# Altium schematic sheet Connectors.SchDoc
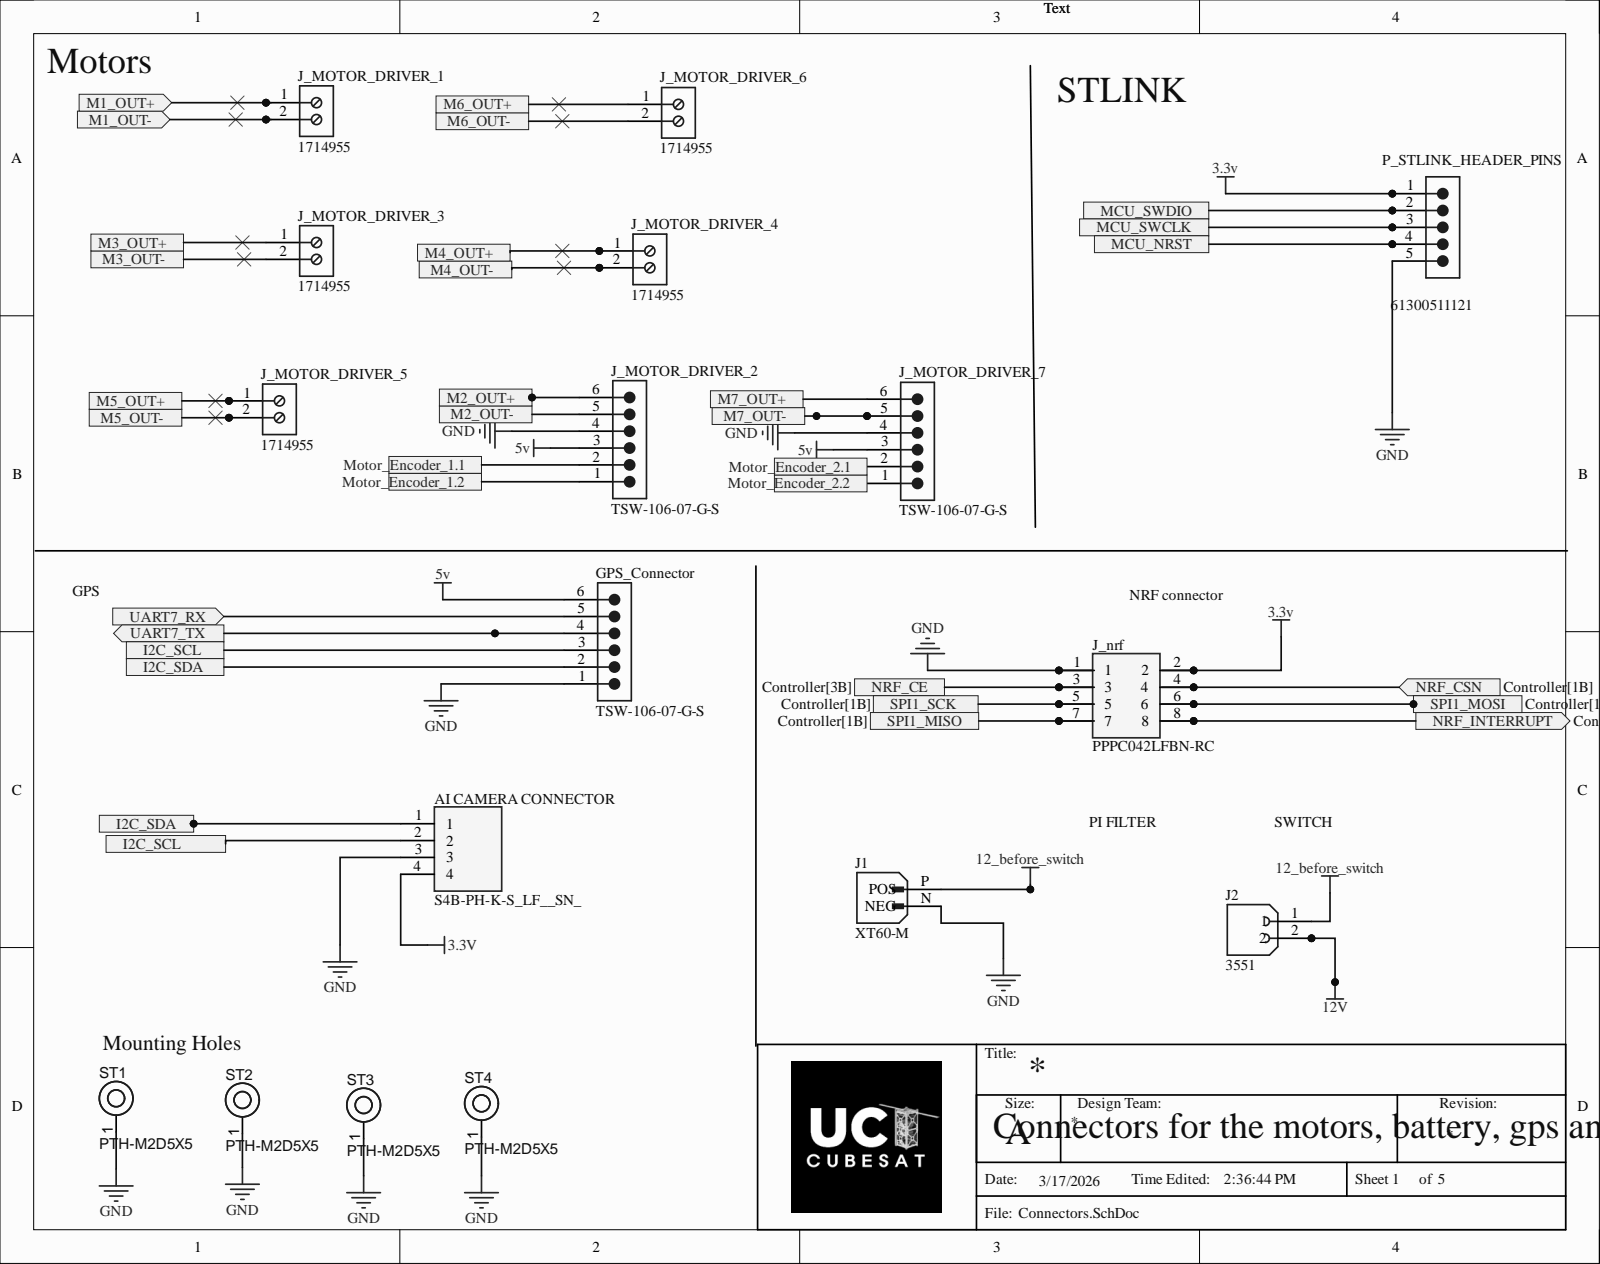
[diagram p0: Connectors.SchDoc]

## Parts
AI_CAMERA_CONNECTOR  S4B-PH-K-S_LF__SN_  [SHDRRA4W50P0X200_1X4_990X760X480P]
GPS_Connector  TSW-106-07-G-S  [SMTC-TSW-106-07-X-S]
J1  XT60-M  [AMASS_XT60-M]
J2  3551  [KEYSTONE_3551]
J_MOTOR_DRIVER_1  1714955  [PHOE-1714955_V]
J_MOTOR_DRIVER_2  TSW-106-07-G-S  [SMTC-TSW-106-07-X-S]
J_MOTOR_DRIVER_3  1714955  [PHOE-1714955_V]
J_MOTOR_DRIVER_4  1714955  [PHOE-1714955_V]
J_MOTOR_DRIVER_5  1714955  [PHOE-1714955_V]
J_MOTOR_DRIVER_6  1714955  [PHOE-1714955_V]
J_MOTOR_DRIVER_7  TSW-106-07-G-S  [SMTC-TSW-106-07-X-S]
J_nrf  PPPC042LFBN-RC  [RHDR8W80P254_2X4_1066X508X850P]
P_STLINK_HEADER_PINS  61300511121  [61300511121]
ST1  PTH-M2D5X5  [PTH-M2D5X5]
ST2  PTH-M2D5X5  [PTH-M2D5X5]
ST3  PTH-M2D5X5  [PTH-M2D5X5]
ST4  PTH-M2D5X5  [PTH-M2D5X5]

## Ports
I2C_SCL, I2C_SDA, M1_OUT+, M1_OUT-, M2_OUT+, M2_OUT-, M3_OUT+, M3_OUT-, M4_OUT+, M4_OUT-, M5_OUT+, M5_OUT-, M6_OUT+, M6_OUT-, M7_OUT+, M7_OUT-, MCU_NRST, MCU_SWCLK, MCU_SWDIO, Motor_Encoder_1.1, Motor_Encoder_1.2, Motor_Encoder_2.1, Motor_Encoder_2.2, NRF_CE, NRF_CSN, NRF_INTERRUPT, SPI1_MISO, SPI1_MOSI, SPI1_SCK, UART7_RX, UART7_TX

## Nets
3.3v: AI_CAMERA_CONNECTOR.4 J_nrf.2 P_STLINK_HEADER_PINS.1
5v: GPS_Connector.6 J_MOTOR_DRIVER_2.3 J_MOTOR_DRIVER_7.3
12_before_switch: J1.P J2.1
12V: J2.2
GND: AI_CAMERA_CONNECTOR.3 GPS_Connector.1 J1.N J_MOTOR_DRIVER_2.4 J_MOTOR_DRIVER_7.4 J_nrf.1 P_STLINK_HEADER_PINS.5 ST1.1 ST2.1 ST3.1 ST4.1
I2C_SCL: AI_CAMERA_CONNECTOR.2 GPS_Connector.3 ; port I2C_SCL
I2C_SDA: AI_CAMERA_CONNECTOR.1 GPS_Connector.2 ; port I2C_SDA
M1_OUT+: J_MOTOR_DRIVER_1.1 ; port M1_OUT+
M1_OUT-: J_MOTOR_DRIVER_1.2 ; port M1_OUT-
M2_OUT+: J_MOTOR_DRIVER_2.6 ; port M2_OUT+
M2_OUT-: J_MOTOR_DRIVER_2.5 ; port M2_OUT-
M3_OUT+: J_MOTOR_DRIVER_3.1 ; port M3_OUT+
M3_OUT-: J_MOTOR_DRIVER_3.2 ; port M3_OUT-
M4_OUT+: J_MOTOR_DRIVER_4.1 ; port M4_OUT+
M4_OUT-: J_MOTOR_DRIVER_4.2 ; port M4_OUT-
M5_OUT+: J_MOTOR_DRIVER_5.1 ; port M5_OUT+
M5_OUT-: J_MOTOR_DRIVER_5.2 ; port M5_OUT-
M6_OUT+: J_MOTOR_DRIVER_6.1 ; port M6_OUT+
M6_OUT-: J_MOTOR_DRIVER_6.2 ; port M6_OUT-
M7_OUT+: J_MOTOR_DRIVER_7.6 ; port M7_OUT+
M7_OUT-: J_MOTOR_DRIVER_7.5 ; port M7_OUT-
MCU_NRST: P_STLINK_HEADER_PINS.4 ; port MCU_NRST
MCU_SWCLK: P_STLINK_HEADER_PINS.3 ; port MCU_SWCLK
MCU_SWDIO: P_STLINK_HEADER_PINS.2 ; port MCU_SWDIO
Motor_Encoder_1.1: J_MOTOR_DRIVER_2.2 ; port Motor_Encoder_1.1
Motor_Encoder_1.2: J_MOTOR_DRIVER_2.1 ; port Motor_Encoder_1.2
Motor_Encoder_2.1: J_MOTOR_DRIVER_7.2 ; port Motor_Encoder_2.1
Motor_Encoder_2.2: J_MOTOR_DRIVER_7.1 ; port Motor_Encoder_2.2
NRF_CE: J_nrf.3 ; port NRF_CE
NRF_CSN: J_nrf.4 ; port NRF_CSN
NRF_INTERRUPT: J_nrf.8 ; port NRF_INTERRUPT
SPI1_MISO: J_nrf.7 ; port SPI1_MISO
SPI1_MOSI: J_nrf.6 ; port SPI1_MOSI
SPI1_SCK: J_nrf.5 ; port SPI1_SCK
UART7_RX: GPS_Connector.5 ; port UART7_RX
UART7_TX: GPS_Connector.4 ; port UART7_TX

## Library data of the parts
AI_CAMERA_CONNECTOR = S4B-PH-K-S_LF__SN_: CONN HEADER R/A 4POS 2MM; Manufacturer_Name: JST (JAPAN SOLDERLESS TERMINALS); Manufacturer_Part_Number: S4B-PH-K-S(LF)(SN); Geometry.Height: 4.8mm; Arrow Part Number: S4B-PH-K-S(LF)(SN); Arrow Price/Stock: https://www.arrow.com/en/products/s4b-ph-k-s-lf-sn/jst-manufacturing?utm_currency=USD&region=europe; Component Kind: Standard; Datasheet Link: https://datasheet.lcsc.com/szlcsc/JST-Sales-America-PHsocket-1-4P-Curved-needle-pitch2mm_C157926.pdf; Library Name: S4B-PH-K-S_LF__SN_.SchLib; Mouser Part Number: N/A; Mouser Price/Stock: https://www.mouser.co.uk/ProductDetail/JST-Commercial/S4B-PH-K-SLFSN?qs=QpmGXVUTftEGA16rwNx4xw%3D%3D; Supplier 1: DigiKey; Supplier Part Number 1: 455-1721-ND
GPS_Connector J_MOTOR_DRIVER_2 J_MOTOR_DRIVER_7 = CMP-1024-00383-1: 0.025" SQ Post Header, Through-hole, Vertical, -55 to 125 degC, 2.54 mm Pitch, 6-Pin, Male, RoHS; Manufacturer: Samtec; Pitch: 2.54 mm; Component Kind: Standard; ComponentLink1Description: Manufacturer URL; ComponentLink1URL: http://www.samtec.com; ComponentLink2Description: Datasheet; ComponentLink2URL: http://www.samtec.com/documents/webfiles/pdf/TSW_TH.PDF; ComponentLink3Description: Package Specification; ComponentLink3URL: http://www.samtec.com/documents/webfiles/cpdf/TSW-XXX-XX-XXX-X-XX-XXX-MKT.pdf; DatasheetVersion: F-214; Library Name: Altium Content Vault; Mounting Technology: Through-hole; Orientation: Vertical; PackageDescription: .025[0.64] SQ. Post Terminal Strip Assembly; PackageReference: TSW-106-07-X-S; PackageVersion: DM, 8/1988; PartNumber: TSW-106-07-G-S; RoHS: True; Temperature Range: -55 to 125 degC
J1 = XT60-M: Plug; DC supply; XT60; male; PIN: 2; for cable; soldered; 30A; 500V; MF: AMASS; Check_prices: https://www.snapeda.com/parts/XT60-M/AMASS/view-part/?ref=eda; Component Kind: Standard; Library Name: XT60-M.SchLib; MP: XT60-M; Package: Package; SnapEDA_Link: https://www.snapeda.com/parts/XT60-M/AMASS/view-part/?ref=snap
J2 = 3551: Female PCB Terminal Accepts .187 x .020 Tabs; MF: Keystone Electronics; Check_prices: https://www.snapeda.com/parts/3551/Keystone/view-part/?ref=eda; Component Kind: Standard; Library Name: 3551.SchLib; MP: 3551; Package: None; SnapEDA_Link: https://www.snapeda.com/parts/3551/Keystone/view-part/?ref=snap
J_MOTOR_DRIVER_1 J_MOTOR_DRIVER_3-J_MOTOR_DRIVER_6 = CMP-2000-05797-1: PC Terminal Block, Pitch 6.35 mm, 1 x 2 Position, Height 21.5 mm, Tail Lenght 5.1 mm, RoHS, Bulk; Manufacturer: Phoenix Contact; Component Kind: Standard; Datasheet URL: http://media.digikey.com/pdf/Data%20Sheets/Phoenix%20Contact%20PDFs/1714955.pdf; Datasheet Version: 02/2010; Library Name: Altium Content Vault; Manufacturer URL: http://www.phoenixcontact.com/; Mounting Technology: ThroughHole; Package Description: PC Terminal Block, Pitch 6.35 mm, 1 x 2 Position, Height 21.5 mm, Tail Lenght 5.1 mm; Package Reference: 1714955
J_nrf = PPPC042LFBN-RC: Connector; Manufacturer_Name: Sullins; Component Kind: Standard; Datasheet Link: http://www.sullinscorp.com/drawings/78_P(N)PxCxxxLFBN-RC,_10492-H.pdf; Height: 8.5mm; Library Name: SamacSys.SchLib; Manufacturer_Part_Number: PPPC042LFBN-RC
P_STLINK_HEADER_PINS = CMP-1502-01068-4: THT Vertical Pin Header WR-PHD, Pitch 2.54 mm, Single Row, 5 pins; Connector Type: Male Pin; Contact Resistance: 20mΩ; Current Rating: 3A; Gender: Male; Number of Contacts: 5; Operating Supply Voltage: 250V; Pitch: 2.54mm; Color: Black; Component Kind: Standard; Contact Material: Gold; Contact Mating Length: 5.9944mm; Contact Plating: Gold; Height: 8.89mm; Lead Free: Lead Free; Lead Pitch: 2.54mm; Library Name: Altium Content Vault; Max Operating Temperature: 105°C; Min Operating Temperature: -40°C; Mount: Through Hole; Number of Pins: 5; Number of Positions: 5; Number of Rows: 1; Orientation: Vertical; Packaging: Bulk; RoHS: Compliant; Termination: Solder; Voltage Rating: 250V
ST1-ST4 = CMP-00028-00004-2: M2 PTH (2.5mm), 5mm pad; Component Kind: Standard; Pins: 1; Library Name: University of California Irvine
